# Altium schematic sheet Sheet2.SchDoc(Sheet2)
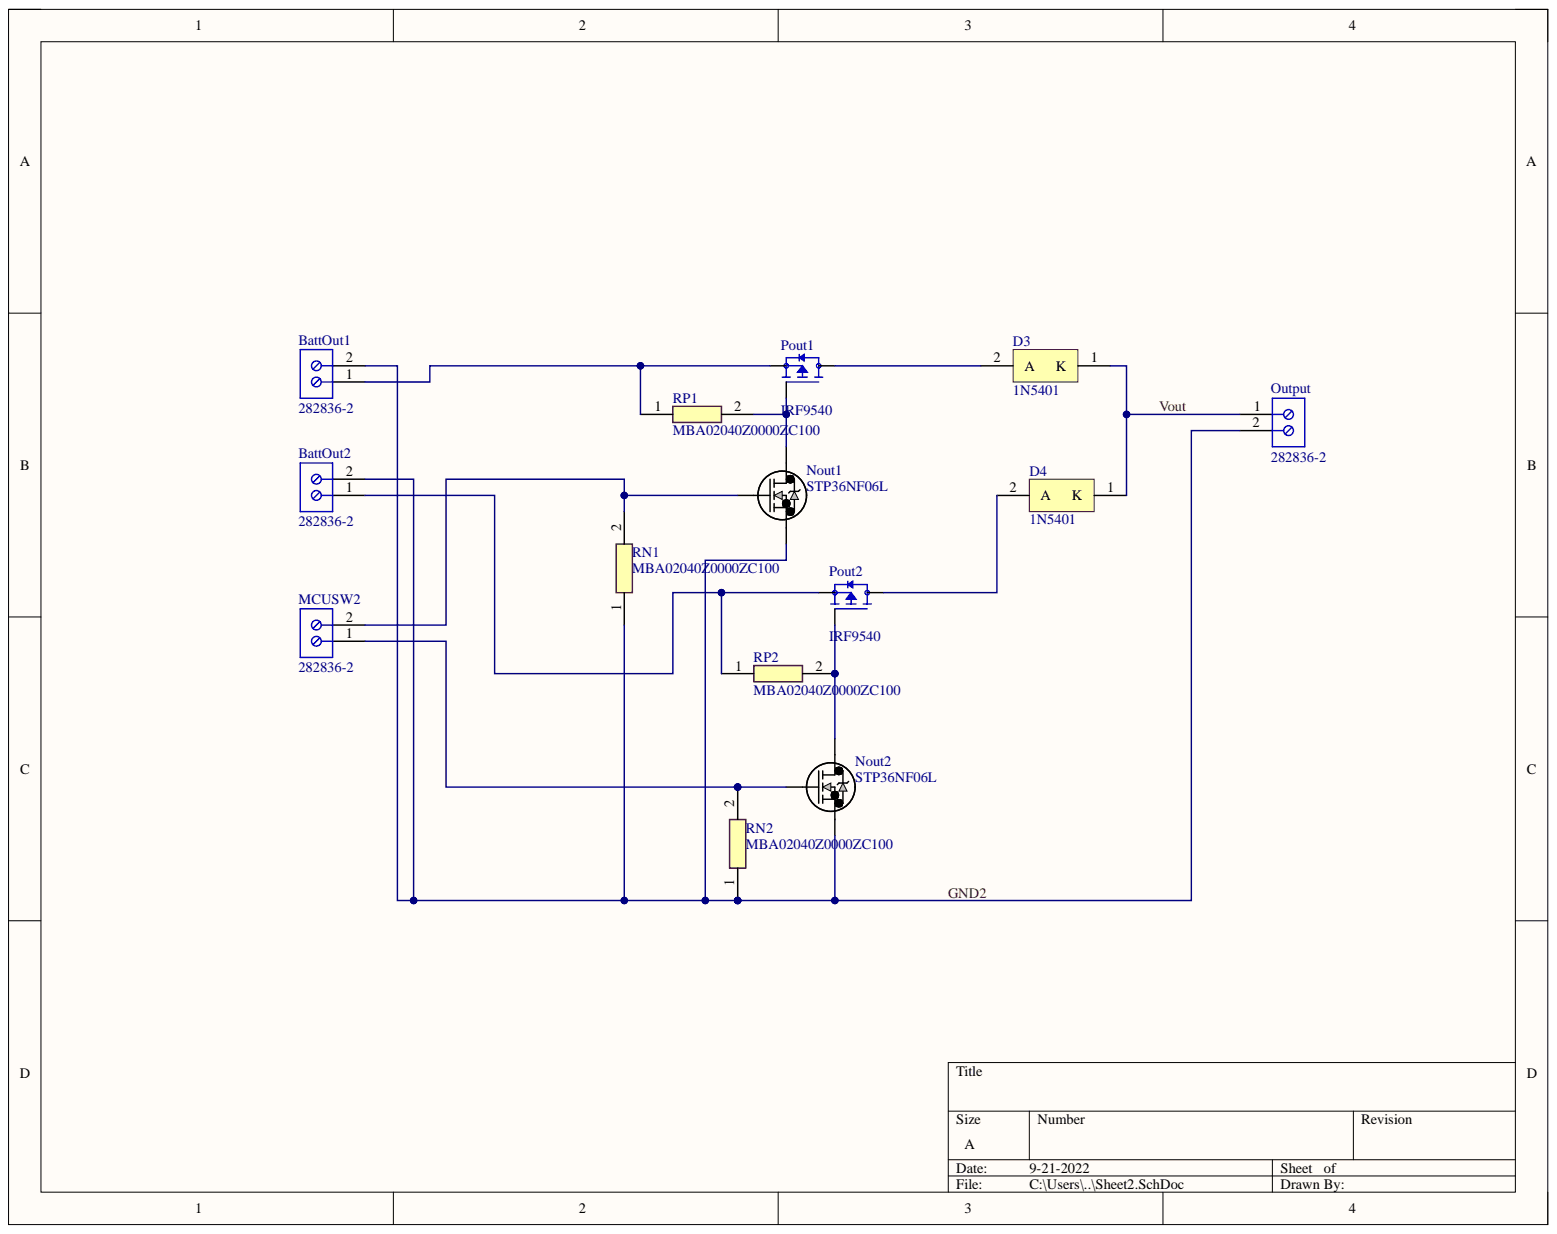
[diagram p0: Sheet2.SchDoc]

## Parts
BattOut1  282836-2  [TECO-282836-2_V]
BattOut2  282836-2  [TECO-282836-2_V]
D3  1N5401  [ONSC-AXIAL_LEAD-2-267-05_V]
D4  1N5401  [ONSC-AXIAL_LEAD-2-267-05_V]
MCUSW2  282836-2  [TECO-282836-2_V]
Nout1  STP36NF06L  [TO-220_STM]
Nout2  STP36NF06L  [TO-220_STM]
Output  282836-2  [TECO-282836-2_V]
Pout1  IRF9540  [TO254P1052X465X1989-3]
Pout2  IRF9540  [TO254P1052X465X1989-3]
RN1  MBA02040Z0000ZC100  [RESAD1000W50L360D160]
RN2  MBA02040Z0000ZC100  [RESAD1000W50L360D160]
RP1  MBA02040Z0000ZC100  [RESAD1000W50L360D160]
RP2  MBA02040Z0000ZC100  [RESAD1000W50L360D160]

## Nets
GND2: BattOut1.2 BattOut2.2 Nout1.3 Nout2.3 Output.2 RN1.1 RN2.1
Vout: D3.1 D4.1 Output.1
NetBattOut1_1: BattOut1.1 Pout1.3 RP1.1
NetBattOut2_1: BattOut2.1 Pout2.3 RP2.1
NetD3_2: D3.2 Pout1.2
NetD4_2: D4.2 Pout2.2
NetMCUSW2_1: MCUSW2.1 Nout2.1 RN2.2
NetMCUSW2_2: MCUSW2.2 Nout1.1 RN1.2
NetNout1_2: Nout1.2 Pout1.1 RP1.2
NetNout2_2: Nout2.2 Pout2.1 RP2.2

## Library data of the parts
BattOut1 BattOut2 MCUSW2 Output = CMP-1684-00017-1: Female Header, Pitch 5 mm, 1 x 2 Position, Height 10 mm, Tail Length 3.5 mm, Rohs, Bulk; Manufacturer: TE Connectivity; 3D Model: 282836-2.pdf; Clamp Material - Plating: Brass-NickelPlated; Current: 13.5A; Dynamic Catalog: BuchananSeries; Featured Product: EurostyleTerminalBlockConnectors; Mating Orientation: HorizontalwithBoard; Number of Levels: 1; Other Names: 5ULV-02B  A98076; Pitch: 0.197"(5.00mm); Positions Per Level: 2; Product Training Modules: Wire-to-BoardEurostyleTerminalBlocks; Screw Thread: M3; Torque - Screw: 0.68Nm(6Lb-In); Voltage: 300V; Wire Gauge: 16-30AWG; Wire Termination: Screw-RisingCageClamp; Category: Connectors,Interconnects; Color: Green; Component Kind: Standard; Contact Material - Plating: Brass-TinPlated; Datasheet URL: http://www.te.com.cn/commerce/DocumentDelivery/DDEController?Action=showdoc&DocId=Catalog+Section%7F1308389_EUROSTYLE_TERMINAL_BLOCKS%7F0607%7Fpdf%7FEnglish%7FENG_CS_1308389_EUROSTYLE_TERMINAL_BLOCKS_0607.pdf%7F282836-2; Family: TerminalBlocks-WiretoBoard; Features: Interlocking(Side); Housing Material: Polyamide(PA66),Nylon6/6; Library Name: Altium Content Vault; Manufacturer URL: http://www.te.com; Mounting Type: ThroughHole; Package Description: Female Header, Pitch 5 mm, 1 x 2 Position, Height 10 mm, Tail Length 3.5 mm; Package Reference: THD; Packaging: Bulk; RoHS Information: 282836-2StatementofCompliance; Screw Material - Plating: Brass-NickelPlated; Series: Buchanan; Standard Package: 300
D3 D4 = CMP-0902-00078-1: Standard Recovery Rectifier, 2-Pin Axial_Lead, Pb-Free, Bulk Box; Manufacturer: On Semiconductor; IFSM Max (A): 200; IO(rec) Max (A): 3; VRRM Max (V): 100; Component Kind: Standard; ComponentLink1Description: Manufacturer URL; ComponentLink1URL: http://www.onsemi.com/; ComponentLink2Description: Datasheet; ComponentLink2URL: http://www.onsemi.com/pub_link/Collateral/1N5400-D.PDF; ComponentLink3Description: Package Specification; ComponentLink3URL: http://www.onsemi.com/pub_link/Collateral/267-05.PDF; DatasheetVersion: Rev. 10; Library Name: Altium Content Vault; Mounting Technology: Through Hole; PackageDescription: 2-Pin, Axial Lead, Diameter 5.05 mm; PackageReference: AXIAL_LEAD-2-267-05; PackageVersion: Rev. G, 06/2000; Packing: Bulk Box; PartNumber: 1N5401; RoHS: TRUE; Type: Standard Recovery
Nout1 Nout2 = STP36NF06L: No Description Available; Mfr_Name: STMicroelectronics; Component Kind: Standard; Digi-Key_Part_Number_1: 497-7522-5-ND; Library Name: Schlib1.SchLib; Manufacturer_Part_Number: STP36NF06L; RefDes: RefDes; Type: DEV; Copyright: Copyright (C) 2022 Ultra Librarian. All rights reserved.
Pout1 Pout2 = IRF9540: P-Channel 100V 19A (Tc) 150W (Tc) Through Hole TO-220AB; MF: Vishay Siliconix; Component Kind: Standard; Digi-Key_Part_Number: IRF9540PBF-ND; Library Name: IRF9540.IntLib; MP: IRF9540; Package: TO-220 International Rectifier; SnapEDA_Link: https://www.snapeda.com/parts/IRF9540/Vishay%20Siliconix/view-part/1087953/?ref=snap
RN1 RN2 RP1 RP2 = MBA02040Z0000ZC100: Resistor; Manufacturer_Name: Vishay; Component Kind: Standard; Datasheet Link: https://www.vishay.com/docs/28766/mbxsma.pdf; Manufacturer_Part_Number: MBA02040Z0000ZC100
